# Altium schematic sheet 09-MCU-Power.SchDoc
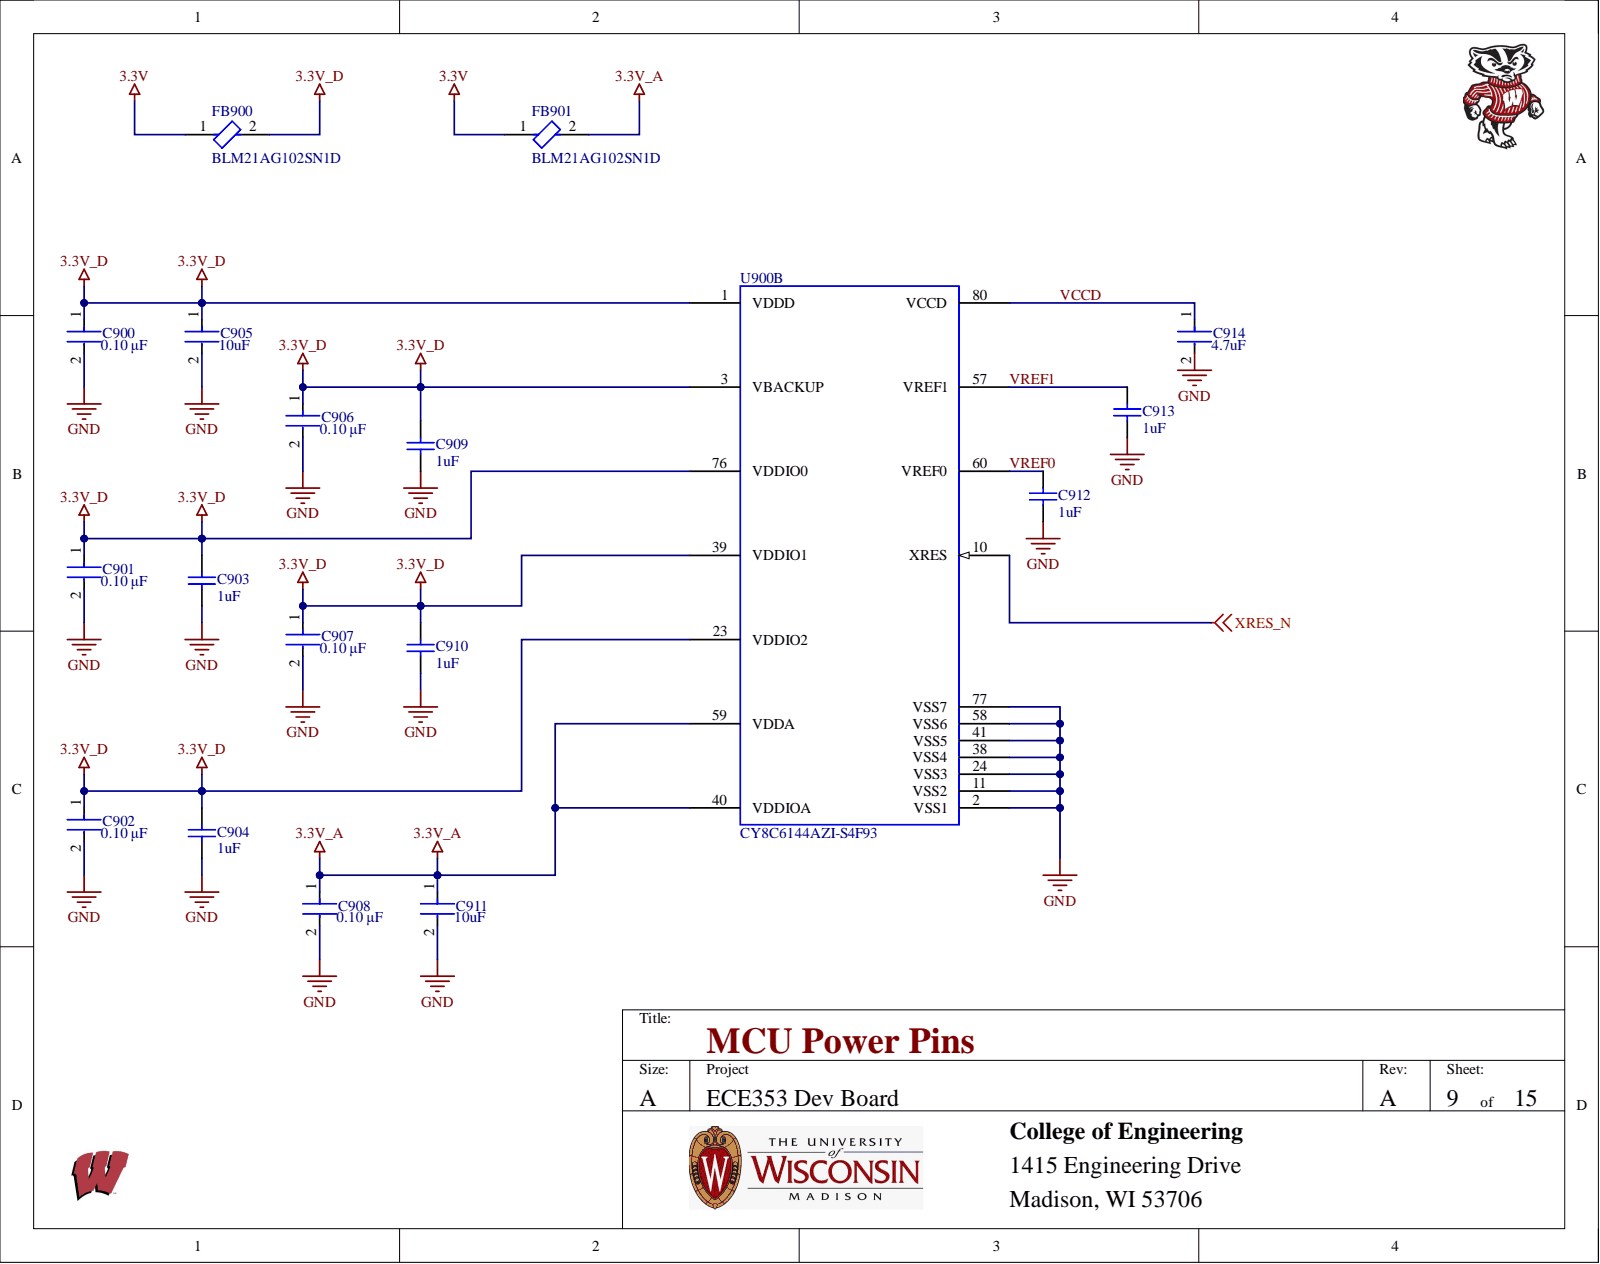
[diagram p0: 09-MCU-Power.SchDoc]

## Parts
C900  value 0.10 μF  [FP-C0805C-DN-MFG]  (hidden comment: C0805C104K5RACTU)
C901  value 0.10 μF  [FP-C0805C-DN-MFG]  (hidden comment: C0805C104K5RACTU)
C902  value 0.10 μF  [FP-C0805C-DN-MFG]  (hidden comment: C0805C104K5RACTU)
C903  value 1uF  [CAPC2013X145X50LL20T25]  (hidden comment: C0805C105K4PACTU)
C904  value 1uF  [CAPC2013X145X50LL20T25]  (hidden comment: C0805C105K4PACTU)
C905  value 10uF  Capacitance: 10uF  [FP-C0805C-DG-MFG]  (hidden comment: C0805C106K8PACTU)
C906  value 0.10 μF  [FP-C0805C-DN-MFG]  (hidden comment: C0805C104K5RACTU)
C907  value 0.10 μF  [FP-C0805C-DN-MFG]  (hidden comment: C0805C104K5RACTU)
C908  value 0.10 μF  [FP-C0805C-DN-MFG]  (hidden comment: C0805C104K5RACTU)
C909  value 1uF  [CAPC2013X145X50LL20T25]  (hidden comment: C0805C105K4PACTU)
C910  value 1uF  [CAPC2013X145X50LL20T25]  (hidden comment: C0805C105K4PACTU)
C911  value 10uF  Capacitance: 10uF  [FP-C0805C-DG-MFG]  (hidden comment: C0805C106K8PACTU)
C912  value 1uF  [CAPC2013X145X50LL20T25]  (hidden comment: C0805C105K4PACTU)
C913  value 1uF  [CAPC2013X145X50LL20T25]  (hidden comment: C0805C105K4PACTU)
C914  Capacitance: 4.7uF  [FP-C0805C475K8PACTU-MFG]  (hidden comment: C0805C475K8PACTU)
FB900  BLM21AG102SN1D  [FP-BLM21-0_2-t0_85-e0_5-MFG]
FB901  BLM21AG102SN1D  [FP-BLM21-0_2-t0_85-e0_5-MFG]
U900  CY8C6144AZI-S4F93  [CY8C6144AZI-S4F93]  (part B)

## Nets
3.3V: FB900.1 FB901.1
3.3V_A: C908.1 C911.1 FB901.2 U900.40(VDDIOA) U900.59(VDDA)
3.3V_D: C900.1 C901.1 C902.1 C903.2 C904.2 C905.1 C906.1 C907.1 C909.2 C910.2 FB900.2 U900.1(VDDD) U900.3(VBACKUP) U900.23(VDDIO2) U900.39(VDDIO1) U900.76(VDDIO0)
GND: C900.2 C901.2 C902.2 C903.1 C904.1 C905.2 C906.2 C907.2 C908.2 C909.1 C910.1 C911.2 C912.1 C913.1 C914.2 U900.2(VSS1) U900.11(VSS2) U900.24(VSS3) U900.38(VSS4) U900.41(VSS5) U900.58(VSS6) U900.77(VSS7)
XRES_N: U900.10(XRES)
VCCD: C914.1 U900.80(VCCD)
VREF0: C912.2 U900.60(VREF0)
VREF1: C913.2 U900.57(VREF1)

## Library data of the parts
C900-C902 C906-C908 = CMP-2007-00279-2: CAP CER 0.1UF 50V X7R 0805; Manufacturer: KEMET; Dielectric Material: Ceramic; Temperature Coefficient: 30ppm/°C; Tolerance: 0.1; Voltage: 500V; Automotive: No; Capacitance: 0.10 μF; Case Code (Imperial): 0805; Case Code (Metric): 2012; Case/Package: 0805; Category: Cap; Component Kind: Standard; ComponentLink2Description: Datasheet; ComponentLink2URL: https://content.kemet.com/datasheets/KEM_C1003_C0G_SMD.pdf; Construction: Flat; Contact Plating: Tin,Matte; Depth: 1.25mm; Device Class L1: Passive Components; Device Class L2: Capacitors; Device Class L3: Ceramic Capacitors; Dielectric: X7R; Digikey Description: CAP CER 0.1UF 50V X7R 0805; Height: 0.88mm; Ipc Land Pattern Name: CAPC200125X78; Lead Free: Yes; Lead Pitch: 0.75mm; Length: 2mm; Library Name: Altium Content Vault; Manufacturer 1: KEMET; Manufacturer Part Number: C0805C104K5RACTU; Manufacturer Part Number 1: C0805C104K5RACTU; Material: Ceramic; Max Operating Temperature: 125°C; Min Operating Temperature: -55°C; Mount: Surface Mount,PCB; Mouser Description: Cap Ceramic 0.1uF 50V X7R 10% SMD 2.0 x 1.2 mm 125 C Paper T/R; Number of Pins: 2; Octopart: https://octopart.com/c0805c104k5ractu-kemet-143926; Package: C0805C-DN; Package Quantity: 4000; Packaging: Tape and Reel; Pricing 1: 1=0.14000, 10=0.09700, 50=0.05480, 100=0.04540, 500=0.03182, 1000=0.02634 (USD); Radiation Hardening: No; Reach SVHC Compliant: Yes; RoHS: TRUE; RoHS Compliant: Yes; Series: SMD Comm X7R; Stock 1: 2163733; Supplier 1: Digi-Key; Supplier Part Number 1: 399-C0805C104K5RAC7800CT-ND; Temperature: -55°C to +125°C; Temperature Characteristic: X7R; Temperature Range High: +125°C; Temperature Range Low: -55°C; Termination: SMD/SMT; Thickness: 0.035inch; Voltage Rating: 50 V; Voltage Rating (DC): 50V; Width: 1.2mm
C903 C904 C909 C910 C912 C913 = CMP-1036-04746-2: Manufacturer: Kemet; Dielectric: X5R; Dielectric Material: Ceramic; Dissipation Factor: 5%; Tolerance: 10%; Voltage: 16V; Case Code (Imperial): 0805; Case Code (Metric): 2012; Case/Package: 0805; Category: Ceramic Capacitors; Component Kind: Standard; ComponentLink1Description: http://www.farnell.com/datasheets/2745930.pdf?src-supplier=Farnell; ComponentLink1URL: http://www.farnell.com/datasheets/2745930.pdf?src-supplier=Farnell; ComponentLink2Description: http://components.arrow.com/part/dataview/43037300S8313636N7429?src-supplier=Arrow+Electronics; ComponentLink2URL: http://components.arrow.com/part/dataview/43037300S8313636N7429?src-supplier=Arrow+Electronics; ComponentLink3Description: https://api.kemet.com/component-edge/download/datasheet/C0805C105K4PAC.pdf?src-supplier=Digi-Key; ComponentLink3URL: https://api.kemet.com/component-edge/download/datasheet/C0805C105K4PAC.pdf?src-supplier=Digi-Key; ComponentLink4Description: http://www.farnell.com/datasheets/2745930.pdf?src-supplier=Element14; ComponentLink4URL: http://www.farnell.com/datasheets/2745930.pdf?src-supplier=Element14; ComponentLink5Description: https://www.verical.com/server-webapp/html/getDatasheet?partID=127986&src-supplier=Verical; ComponentLink5URL: https://www.verical.com/server-webapp/html/getDatasheet?partID=127986&src-supplier=Verical; ComponentLink6Description: https://search.kemet.com/component-edge/download/datasheet/C0805C105K4PAC.pdf?src-supplier=Allied+Electronics; ComponentLink6URL: https://search.kemet.com/component-edge/download/datasheet/C0805C105K4PAC.pdf?src-supplier=Allied+Electronics; ComponentLink7Description: http://www.farnell.com/datasheets/1824493.pdf?src-supplier=Newark; ComponentLink7URL: http://www.farnell.com/datasheets/1824493.pdf?src-supplier=Newark; ComponentLink8Description: http://www.farnell.com/datasheets/2745930.pdf?src-supplier=Newark; ComponentLink8URL: http://www.farnell.com/datasheets/2745930.pdf?src-supplier=Newark; ComponentLink9Description: https://search.kemet.com/component-edge/download/datasheet/C0805C105K4PAC.pdf?src-supplier=Avnet; ComponentLink9URL: https://search.kemet.com/component-edge/download/datasheet/C0805C105K4PAC.pdf?src-supplier=Avnet; ComponentLink10Description: http://www.kemet.com/Lists/ProductCatalog/Attachments/19/KEM_C1006_X5R_SMD.pdf?src-supplier=Avnet; ComponentLink10URL: http://www.kemet.com/Lists/ProductCatalog/Attachments/19/KEM_C1006_X5R_SMD.pdf?src-supplier=Avnet; ComponentLink11Description: https://search.kemet.com/component-edge/download/datasheet/C0805C105K4PAC.pdf?src-supplier=Mouser; ComponentLink11URL: https://search.kemet.com/component-edge/download/datasheet/C0805C105K4PAC.pdf?src-supplier=Mouser; ComponentLink12Description: https://search.kemet.com/component-edge/download/datasheet/C0805C105K4PAC.pdf?src-supplier=TTI; ComponentLink12URL: https://search.kemet.com/component-edge/download/datasheet/C0805C105K4PAC.pdf?src-supplier=TTI; Construction: Flat; Depth: 1.25mm; Height: 1.25mm; Lead Free: Lead Free; Length: 2mm; Library Name: C0805C105K4PACTU.SchLib; Manufacturer Part Number: C0805C105K4PACTU; Max Operating Temperature: 85°C; Min Operating Temperature: -55°C; Mounting Technology: Surface Mount,PCB; Package Quantity: 2500; Packaging: Tape and Reel; Part Number: C0805C105K4PACTU; Pricing: 1=0.2, 10=0.136, 50=0.0814, 100=0.0687, 500=0.047, 1000=0.04158 (USD); Radiation Hardening: No; Reach SVHC Compliant: No; RoHS: Yes; RoHS Compliant: Yes; Series: C0805C; Stock: 65284; Supplier: Digi-Key; Supplier Part Number: 399-C0805C105K4PAC7800CT-ND; Termination: SMD/SMT; Thickness: 0.055inch; Voltage Rating: 16V; Voltage Rating (DC): 16V; Width: 1.2mm
C905 C911 = CMP-2007-03243-2: CAP CER 10UF 10V X5R 0805; 3DS Part Number: 220-00001; Manufacturer: Kemet; Dielectric Material: Ceramic; Dissipation Factor: 10%; Temperature Coefficient: 15%; Automotive: No; Case Code (Imperial): 0805; Case Code (Metric): 2012; Case/Package: 0805; Category: Cap; Component Kind: Standard; ComponentLink2Description: Datasheet; ComponentLink2URL: https://content.kemet.com/datasheets/KEM_C1006_X5R_SMD.pdf; Construction: Flat; Contact Plating: Tin,Matte; Depth: 1.25mm; Device Class L1: Passive Components; Device Class L2: Capacitors; Device Class L3: Ceramic Capacitors; Dielectric: X5R; Digikey Description: CAP CER 10UF 10V X5R 0805; Height: 1.4mm; Ipc Land Pattern Name: CAPC200125X125; Lead Free: Yes; Length: 2mm; Library Name: Altium Content Vault; Manufacturer 1: KEMET; Manufacturer Part Number: C0805C106K8PACTU; Manufacturer Part Number 1: C0805C106K8PACTU; Material: Ceramic; Max Operating Temperature: 85°C; Min Operating Temperature: -55°C; Mount: Surface Mount; Mouser Description: CAP CER 10UF 10V X5R 0805; Number of Pins: 2; Octopart: https://octopart.com/c0805c106k8pactu-kemet-98697; Package: C0805C-DG; Package Quantity: 1; Packaging: Tape and Reel; Pricing 1: 1=0.14000, 10=0.10300, 50=0.05840, 100=0.04830, 500=0.03388, 1000=0.02805 (USD); Radiation Hardening: No; Reach SVHC Compliant: Yes; RoHS: TRUE; RoHS Compliant: Yes; Series: C; Stock 1: 8391826; Supplier 1: Digi-Key; Supplier Part Number 1: 399-C0805C106K8PAC7800CT-ND; Temperature: -55°C to +85°C; Temperature Characteristic: X5R; Temperature Range High: +85°C; Temperature Range Low: -55°C; Termination: SMD/SMT; Thickness: 0.055inch; Tolerance: 10%; Voltage: 10V; Voltage Rating: 10V; Voltage Rating (DC): 10V; Width: 0.049inch
C914 = CMP-2007-01007-2: CAP CER 4.7UF 10V X5R 0805; Manufacturer 1: KEMET; Dielectric Material: Ceramic; Dissipation Factor: 5%; Tolerance: 10%; Case Code (Imperial): 0805; Case Code (Metric): 2012; Case/Package: 0805; Category: Ceramic Capacitors; Component Kind: Standard; ComponentLink1Description: https://datasheet.ciiva.com/6126/40889-6126699.pdf?src-supplier=Farnell; ComponentLink1URL: https://datasheet.ciiva.com/6126/40889-6126699.pdf?src-supplier=Farnell; ComponentLink2Description: https://datasheet.ciiva.com/18193/getdatasheetpartid-179373-18193909.pdf?src-supplier=Verical; ComponentLink2URL: https://datasheet.ciiva.com/18193/getdatasheetpartid-179373-18193909.pdf?src-supplier=Verical; ComponentLink3Description: https://datasheet.ciiva.com/7842/40889-7842616.pdf?src-supplier=Element14; ComponentLink3URL: https://datasheet.ciiva.com/7842/40889-7842616.pdf?src-supplier=Element14; ComponentLink4Description: https://datasheet.ciiva.com/28614/1918694-28614763.pdf?src-supplier=Element14; ComponentLink4URL: https://datasheet.ciiva.com/28614/1918694-28614763.pdf?src-supplier=Element14; ComponentLink5Description: https://datasheet.ciiva.com/46385/1791935-46385676.pdf?src-supplier=Element14; ComponentLink5URL: https://datasheet.ciiva.com/46385/1791935-46385676.pdf?src-supplier=Element14; Construction: Flat; Depth: 1.25mm; Dielectric: X5R; Height: 1.25mm; Lead Free: Lead Free; Lead Pitch: 0.75mm; Length: 2mm; Library Name: Altium Content Vault; Manufacturer Part Number 1: C0805C475K8PACTU; Max Operating Temperature: 85°C; Min Operating Temperature: -55°C; Mount: Surface Mount; Number of Pins: 2; Package Quantity: 1; Packaging: Tape and Reel; Pricing 1: 1=0.23000, 10=0.15700, 50=0.09400, 100=0.07940, 500=0.05436, 1000=0.04809 (USD); Radiation Hardening: No; REACH SVHC: No SVHC; Reach SVHC Compliant: Yes; RoHS: Yes; RoHS Compliant: Yes; Series: C; Stock 1: 529110; Supplier 1: Digi-Key; Supplier Part Number 1: 399-C0805C475K8PAC7800CT-ND; Termination: SMD/SMT; Thickness: 0.055inch; Voltage: 10V; Voltage Rating: 10V; Voltage Rating (DC): 10V; Width: 1.2mm
FB900 FB901 = CMP-0686-00665-3: Chip Ferrite Bead, 0805, 1000Ω @ 100MHz, 0.27Ω, 25%, 600mA; Manufacturer 1: Murata; Current Rating: 500mA; DC Current: 200mA; DC Resistance (DCR): 280mR; Impedance: 1kR; Material: Steel; Number of Circuits: 1; Shielding: Unshielded; Case Code (Imperial): 0805; Case Code (Metric): 2012; Case/Package: 0805; Category: Ferrite Beads and Chips; Component Kind: Standard; Core Material: Ferrite; DC Resistance-Max: 280mR; Depth: 1.25mm; Frequency: 100MHz; Height: 0.85mm; Lead Free: Lead Free; Length: 2mm; Library Name: Altium Content Vault; Manufacturer Part Number 1: BLM21AG102SN1D; Max Current Rating: 600mA; Max DC Current: 200mA; Max Operating Temperature: 125°C; Military Standard: Not; Min Operating Temperature: -55°C; Number of Lines: 1; Number of Pins: 2; Package Quantity: 1; Packaging: Tape and Reel; Pricing 1: 1=0.16000, 10=0.13000, 25=0.10080, 50=0.08340, 100=0.07200, 250=0.05756, 500=0.05182, 1000=0.04318 (USD); Radiation Hardening: No; REACH SVHC: No SVHC; Reach SVHC Compliant: Yes; RoHS: Yes; RoHS Compliant: Yes; Series: BLM21A; Stock 1: 52844; Supplier 1: Digi-Key; Supplier Part Number 1: 490-1041-1-ND; Termination: SMD/SMT; Test Frequency: 100MHz; Tolerance: 25%; Weight: 0.000194oz; Width: 1.25mm
U900 = CY8C6144AZI-S4F93: Mcu, 32 Bit, 150MHZ, TQFP-80;; Manufacturer 1: Infineon; Category: Microcontrollers; Component Kind: Standard; Library Name: ece353-2022.SchLib; Manufacturer Part Number 1: CY8C6144AZI-S4F93; Pricing 1: 1=9.31000, 10=8.40600, 25=8.01560, 119=6.95983, 238=6.64702, 476=6.06050, 952=5.95000 (USD); RoHS: No; Stock 1: 228; Supplier 1: Digi-Key; Supplier Part Number 1: 448-CY8C6144AZI-S4F93-ND
